# Altium schematic sheet SBMicrocontroller.SchDoc(SBMicrocontroller)
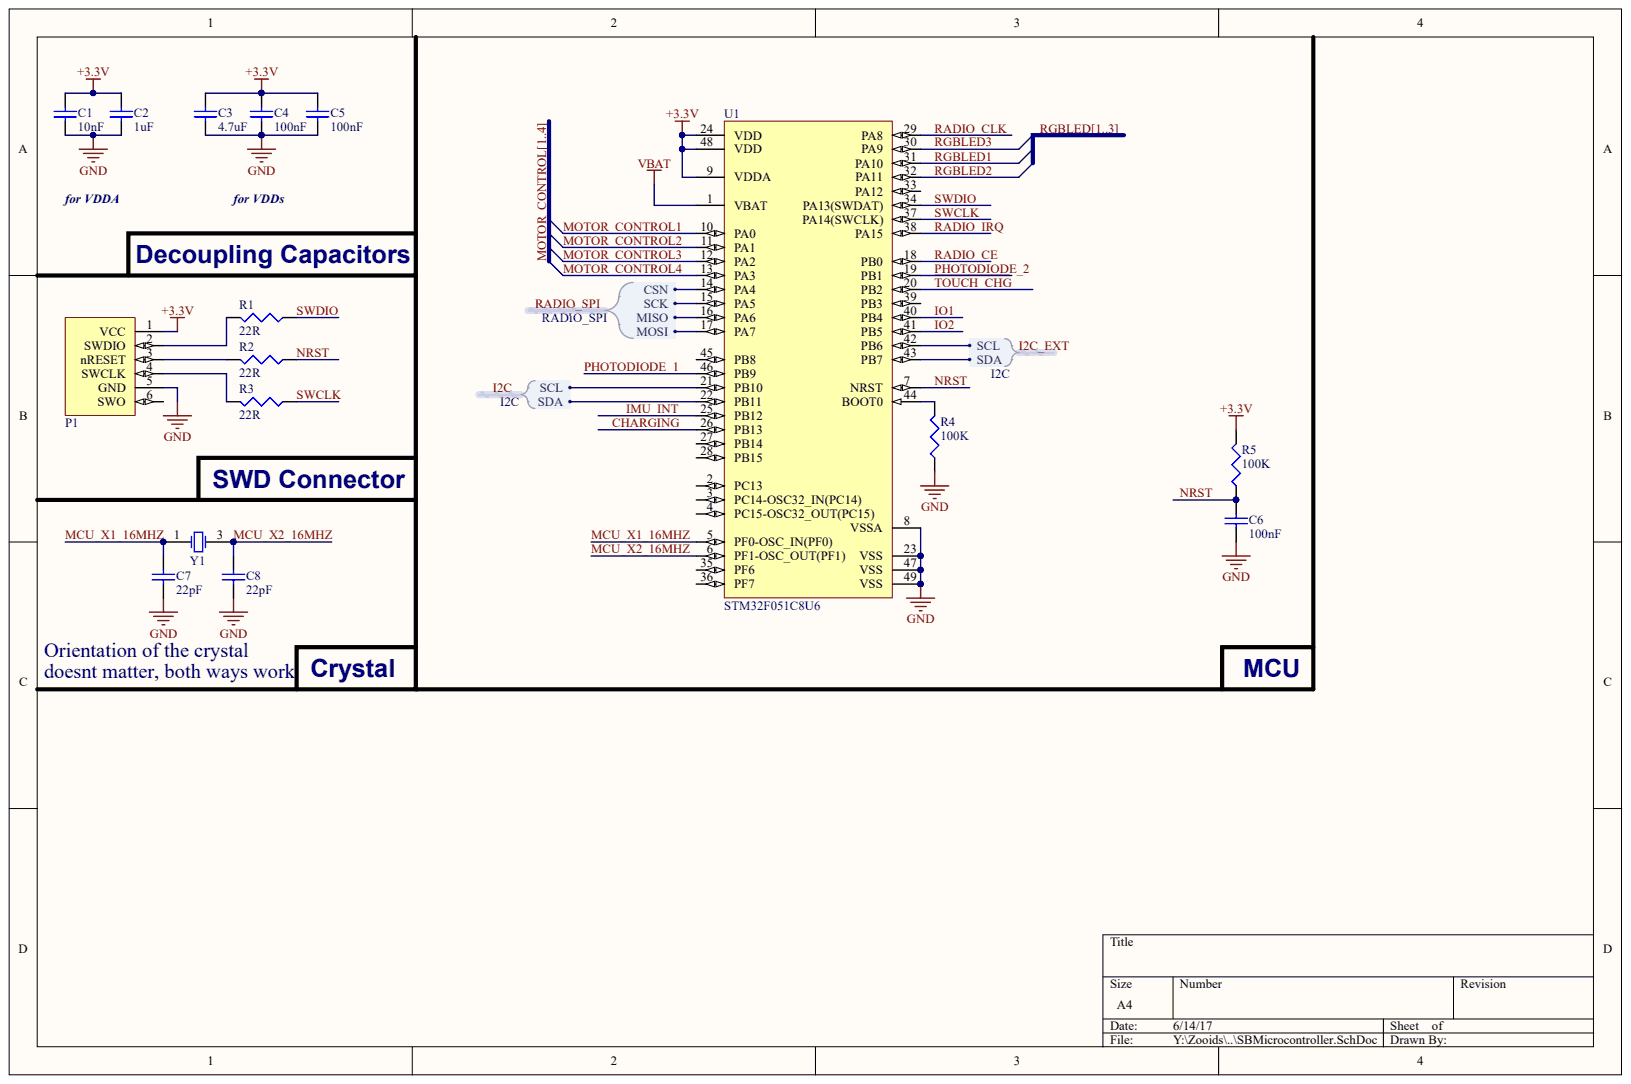
[diagram p0: SBMicrocontroller.SchDoc]

## Parts
C1  10nF  [0402]
C2  1uF  [0603]
C3  4.7uF  [0603]
C4  100nF  [0402]
C5  100nF  [0402]
C6  100nF  [0402]
C7  22pF  [0402]
C8  22pF  [0402]
P1  TC2030-IDC-NL  [TC2030-IDC-NL]  (value not drawn)
R1  22R  [0402]
R2  22R  [0402]
R3  22R  [0402]
R4  100K  [0402]
R5  100K  [0402]
U1  STM32F051C8U6  [QFN50P700X700X60_HS-49L]
Y1  7V-16.000MAAE-T  [3.2x2.5 CRYSTAL]  (value not drawn)

## Nets
+3.3V: C1.2 C2.2 C3.2 C4.2 C5.2 P1.1(VCC) R5.2 U1.9(VDDA) U1.24(VDD) U1.48(VDD)
GND: C1.1 C2.1 C3.1 C4.1 C5.1 C6.1 C7.1 C8.1 P1.5(GND) R4.1 U1.8(VSSA) U1.23(VSS) U1.47(VSS) U1.49(VSS) Y1.2 Y1.4
VBAT: U1.1(VBAT)
CHARGING: U1.26(PB13)
I2C.SCL: U1.21(PB10)
I2C.SDA: U1.22(PB11)
I2C_EXT.SCL: U1.42(PB6)
I2C_EXT.SDA: U1.43(PB7)
IMU_INT: U1.25(PB12)
IO1: U1.40(PB4)
IO2: U1.41(PB5)
MCU_X1_16MHZ: C7.2 U1.5(PF0-OSC_IN(PF0)) Y1.1
MCU_X2_16MHZ: C8.2 U1.6(PF1-OSC_OUT(PF1)) Y1.3
MOTOR_CONTROL1: U1.10(PA0)  [also labelled MOTOR_CONTROL]
MOTOR_CONTROL2: U1.11(PA1)  [also labelled MOTOR_CONTROL]
MOTOR_CONTROL3: U1.12(PA2)  [also labelled MOTOR_CONTROL]
MOTOR_CONTROL4: U1.13(PA3)  [also labelled MOTOR_CONTROL]
NRST: C6.2 R2.1 R5.1 U1.7(NRST)
PHOTODIODE_1: U1.46(PB9)
PHOTODIODE_2: U1.19(PB1)
RADIO_CE: U1.18(PB0)
RADIO_CLK: U1.29(PA8)
RADIO_IRQ: U1.38(PA15)
RADIO_SPI.CSN: U1.14(PA4)
RADIO_SPI.MISO: U1.16(PA6)
RADIO_SPI.MOSI: U1.17(PA7)
RADIO_SPI.SCK: U1.15(PA5)
RGBLED1: U1.31(PA10)  [also labelled RGBLED]
RGBLED2: U1.32(PA11)  [also labelled RGBLED]
RGBLED3: U1.30(PA9)  [also labelled RGBLED]
SWCLK: R3.1 U1.37(PA14(SWCLK))
SWDIO: R1.1 U1.34(PA13(SWDAT))
~{TOUCH_CHG}: U1.20(PB2)
NetP1_2: P1.2(SWDIO) R1.2
NetP1_3: P1.3(nRESET) R2.2
NetP1_4: P1.4(SWCLK) R3.2
NetR4_2: R4.2 U1.44(BOOT0)

Not connected: P1 6(SWO); U1 2(PC13) 3(PC14-OSC32_IN(PC14)) 4(PC15-OSC32_OUT(PC15)) 27(PB14) 28(PB15) 33(PA12) 35(PF6) 36(PF7) 39(PB3) 45(PB8)

## Library data of the parts
C1 = 10nF: 10nF 0402 X7R 25V ±5%; Component Kind: Standard; Library Name: SBComponentsSchematics.SchLib; Supplier 1: Farnell; Supplier 2: Digi-Key; Supplier Part Number 1: 1692285; Supplier Part Number 2: 399-1279-1-ND; Value: 10nF
C2 = 1uF: 1uF 0603 X5R 10V ±10%; Component Kind: Standard; Library Name: SBComponentsSchematics.SchLib; Supplier 1: Farnell; Supplier 2: Digi-Key; Supplier Part Number 1: 1759399; Supplier Part Number 2: 478-1251-1-ND; Value: 1uF
C3 = 4.7uF: 4.7uF 0603 X5R 10V ±10%; Component Kind: Standard; Library Name: SBComponentsSchematics.SchLib; Supplier 1: Farnell; Supplier 2: Digi-Key; Supplier Part Number 1: 2362098; Supplier Part Number 2: 445-5170-1-ND; Value: 4.7uF
C4-C6 = 100nF: 100nF 0402 X7R 16V ±10%; Component Kind: Standard; Library Name: SBComponentsSchematics.SchLib; Supplier 1: Farnell; Supplier 2: Digi-Key; Supplier Part Number 1: 1288252; Supplier Part Number 2: 399-3521-1-ND; Value: 100nF
C7 C8 = 22pF: 22pF 0402 NP0/CG0 50V ±5%; Component Kind: Standard; Library Name: SBComponentsSchematics.SchLib; Supplier 1: Farnell; Supplier 2: Digi-Key; Supplier Part Number 1: 1414580; Supplier Part Number 2: 490-5868-1-ND; Value: 22pF
P1 = TC2030-IDC-NL: TagConnect TC2030-IDC-NL SWD Programming Connector; Component Kind: Standard; Library Name: SBComponentsSchematics.SchLib
R1-R3 = 22R: 22R 0402 1/16W ±1%; Component Kind: Standard; Library Name: SBComponentsSchematics.SchLib; Supplier 1: Farnell; Supplier 2: Digi-Key; Supplier Part Number 1: 2072797; Supplier Part Number 2: 541-22.0LCT-ND; Value: 22R
R4 R5 = 100K: 100K 0402 1/16W ±1%; Component Kind: Standard; Library Name: SBComponentsSchematics.SchLib; Supplier 1: Farnell; Supplier 2: Digi-Key; Supplier Part Number 1: 2072519; Supplier Part Number 2: 541-100KLCT-ND; Value: 100K
U1 = STM32F051C8U6: STMICROELECTRONICS  STM32F051C8U6  MCU 32BIT CORTEX-M0 48MHZ UFQFPN-48; Component Kind: Standard; Library Name: SBComponentsSchematics.SchLib; Supplier 1: Farnell; Supplier 2: Digi-Key; Supplier Part Number 1: 2393638; Supplier Part Number 2: STM32F051C8U6-ND
Y1 = 7V-16.000MAAE-T: TXC - 7V-16.000MAAE-T, 16MHZ, 30PPM, 12PF - CRYSTAL; Component Kind: Standard; Library Name: SBComponentsSchematics.SchLib; Supplier 1: Digi-Key; Supplier 2: Farnell; Supplier Part Number 1: 887-1462-1-ND; Supplier Part Number 2: 1892172
